# Altium schematic sheet Controller.SchDoc
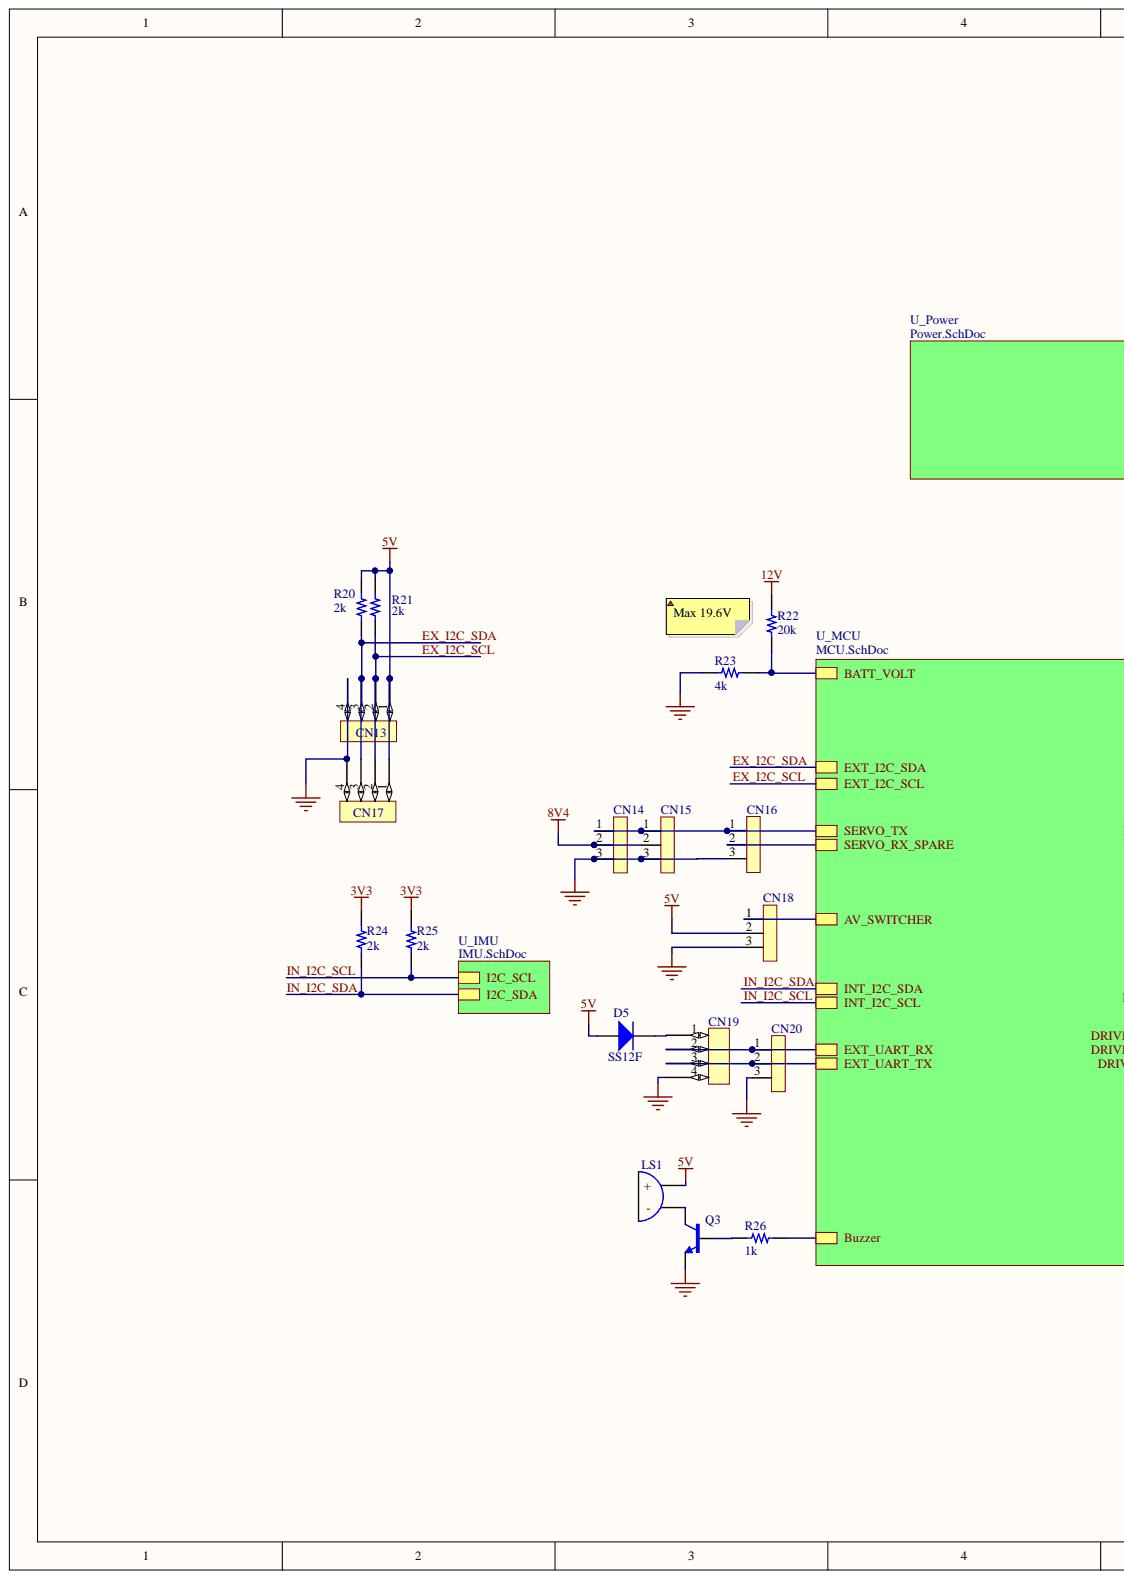
[diagram p0: Controller.SchDoc, left]
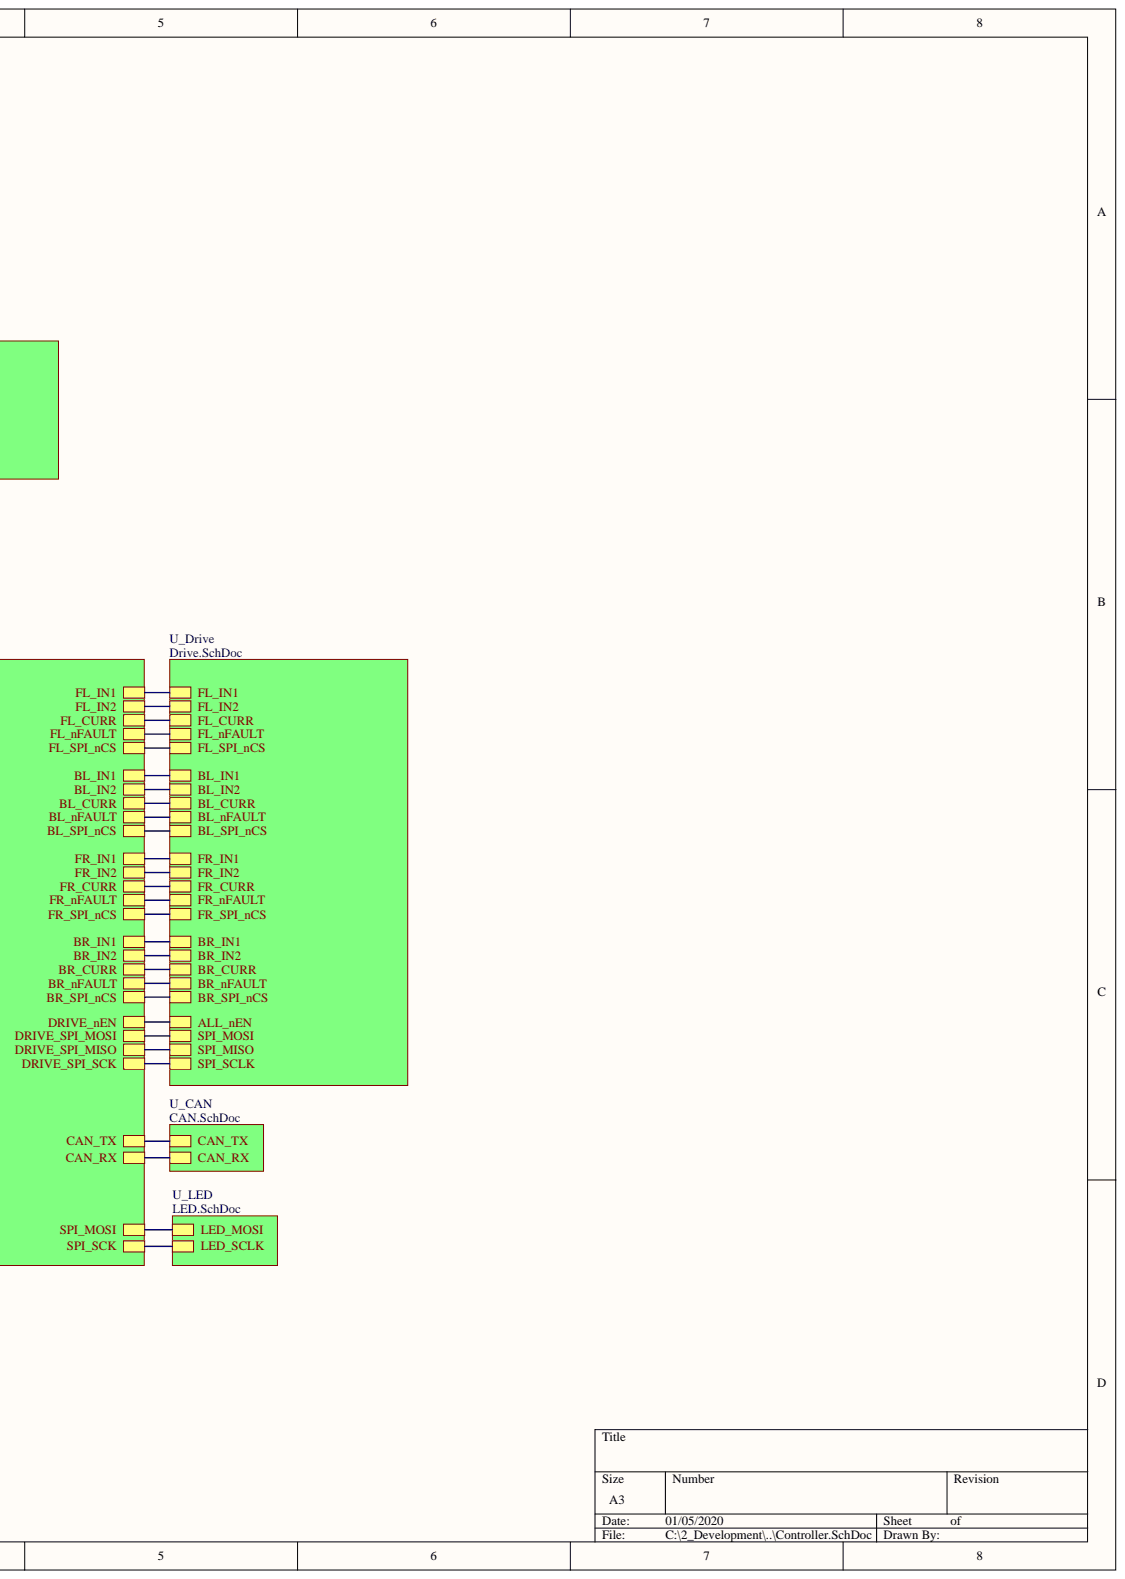
[diagram p1: Controller.SchDoc, right]

## Parts
CN13  JST_GH-4P  [JST_GH-4P]  (value not drawn)
CN14  3P-2.5mm  [2.5mm-3P]  (value not drawn)
CN15  3P-2.5mm  [2.5mm-3P]  (value not drawn)
CN16  3P-2.5mm  [2.5mm-3P]  (value not drawn)
CN17  JST_GH-4P  [JST_GH-4P]  (value not drawn)
CN18  3P-2.5mm  [2.5mm-3P]  (value not drawn)
CN19  JST_GH-4P  [JST_GH-4P]  (value not drawn)
CN20  3P-2.5mm  [2.5mm-3P]  (value not drawn)
D5  SS12F  [SMAF_DIODE]
LS1  Buzzer  [12-dia-buzzer]  (value not drawn)
Q3  S8050  [SOT23-FET]  (value not drawn)
R20  2k  [2010_SMD_Resistor]
R21  2k  [2010_SMD_Resistor]
R22  20k  [0805 - duplicate]
R23  4k  [0805 - duplicate]
R24  2k  [0805 - duplicate]
R25  2k  [0805 - duplicate]
R26  1k  [0805 - duplicate]

## Nets
3V3: R24.2 R25.2
5V: CN13.1 CN17.1 CN18.2 D5.1 LS1.A R20.2 R21.2
8V4: CN14.2 CN15.2
12V: R22.2
GND: CN13.4 CN14.3 CN15.3 CN16.3 CN17.4 CN18.3 CN19.4 CN20.3 Q3.2 R23.2
EX_I2C_SCL: CN13.2 CN17.2 R21.1
EX_I2C_SDA: CN13.3 CN17.3 R20.1
IN_I2C_SCL: R25.1
IN_I2C_SDA: R24.1
NetCN14_1: CN14.1 CN15.1 CN16.1
NetCN16_2: CN16.2
NetCN18_1: CN18.1
NetCN19_1: CN19.1 D5.2
NetCN19_2: CN19.2 CN20.1
NetCN19_3: CN19.3 CN20.2
NetLS1_K: LS1.K Q3.3
NetQ3_1: Q3.1 R26.1
NetR22_1: R22.1 R23.1
NetR26_2: R26.2
ALL_nEN:
BL_CURR:
BL_IN1:
BL_IN2:
BL_nFAULT:
BL_SPI_nCS:
BR_CURR:
BR_IN1:
BR_IN2:
BR_nFAULT:
BR_SPI_nCS:
CAN_RX:
CAN_TX:
FL_CURR:
FL_IN1:
FL_IN2:
FL_nFAULT:
FL_SPI_nCS:
FR_CURR:
FR_IN1:
FR_IN2:
FR_nFAULT:
FR_SPI_nCS:
LED_MOSI:
LED_SCLK:
SPI_MISO:
SPI_MOSI:
SPI_SCLK:

## Library data of the parts
Q3 = S8050: MF: MICROSS/On Semiconductor; MP: 2N2222; Component Kind: Standard; Digi-Key_Part_Number: 1086-15156-ND; Digi-Key_Purchase_URL: https://www.digikey.com.mx/product-detail/en/microsemi-corporation/JANS2N2222A/1086-15156-ND/4384334?utm_source=snapeda&utm_medium=aggregator&utm_campaign=symbol; Library Name: Parts.SchLib; Package: TO-18-3 NJS; SnapEDA_Link: https://www.snapeda.com/parts/2N2222/MICROSS/On%20Semiconductor/view-part/561967/?ref=snap
R20-R26 = Resistor: Component Kind: Standard; Library Name: Parts.SchLib
CN13 CN17 CN19 = JST_GH-4P: Component Kind: Standard; Library Name: Parts.SchLib
CN14-CN16 CN18 CN20 = 3P-2.5mm: Component Kind: Standard; Library Name: Parts.SchLib
D5 = SS12F: Component Kind: Standard; Library Name: Parts.SchLib
LS1 = Buzzer: Component Kind: Standard; Library Name: Parts.SchLib
